# Altium schematic sheet B216.SchDoc(B216)
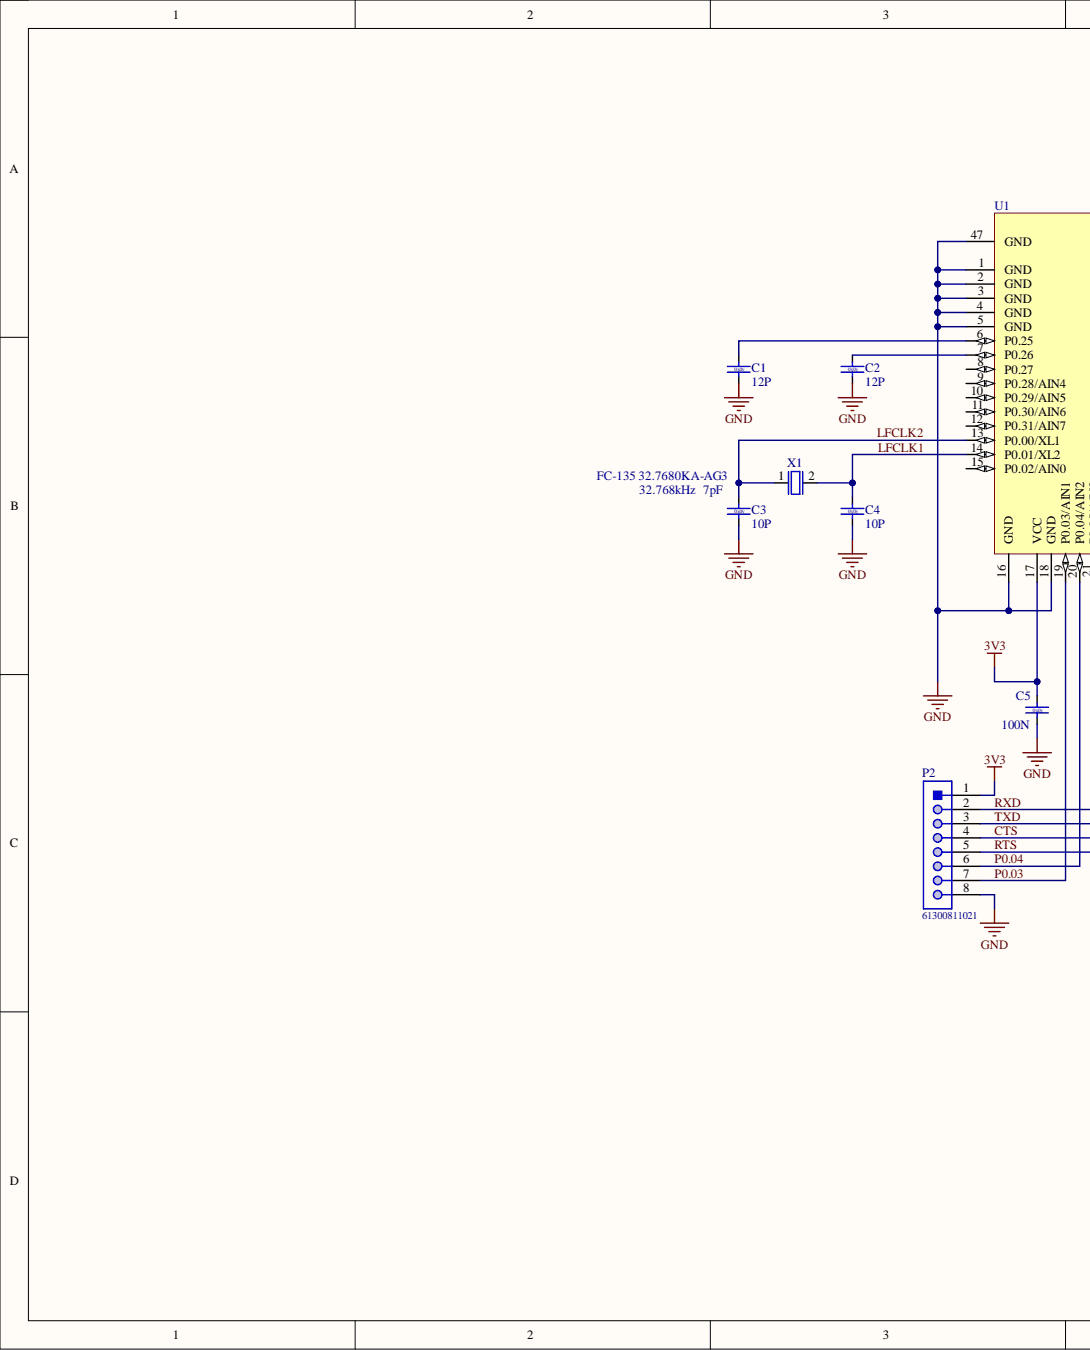
[diagram p0: B216.SchDoc, left]
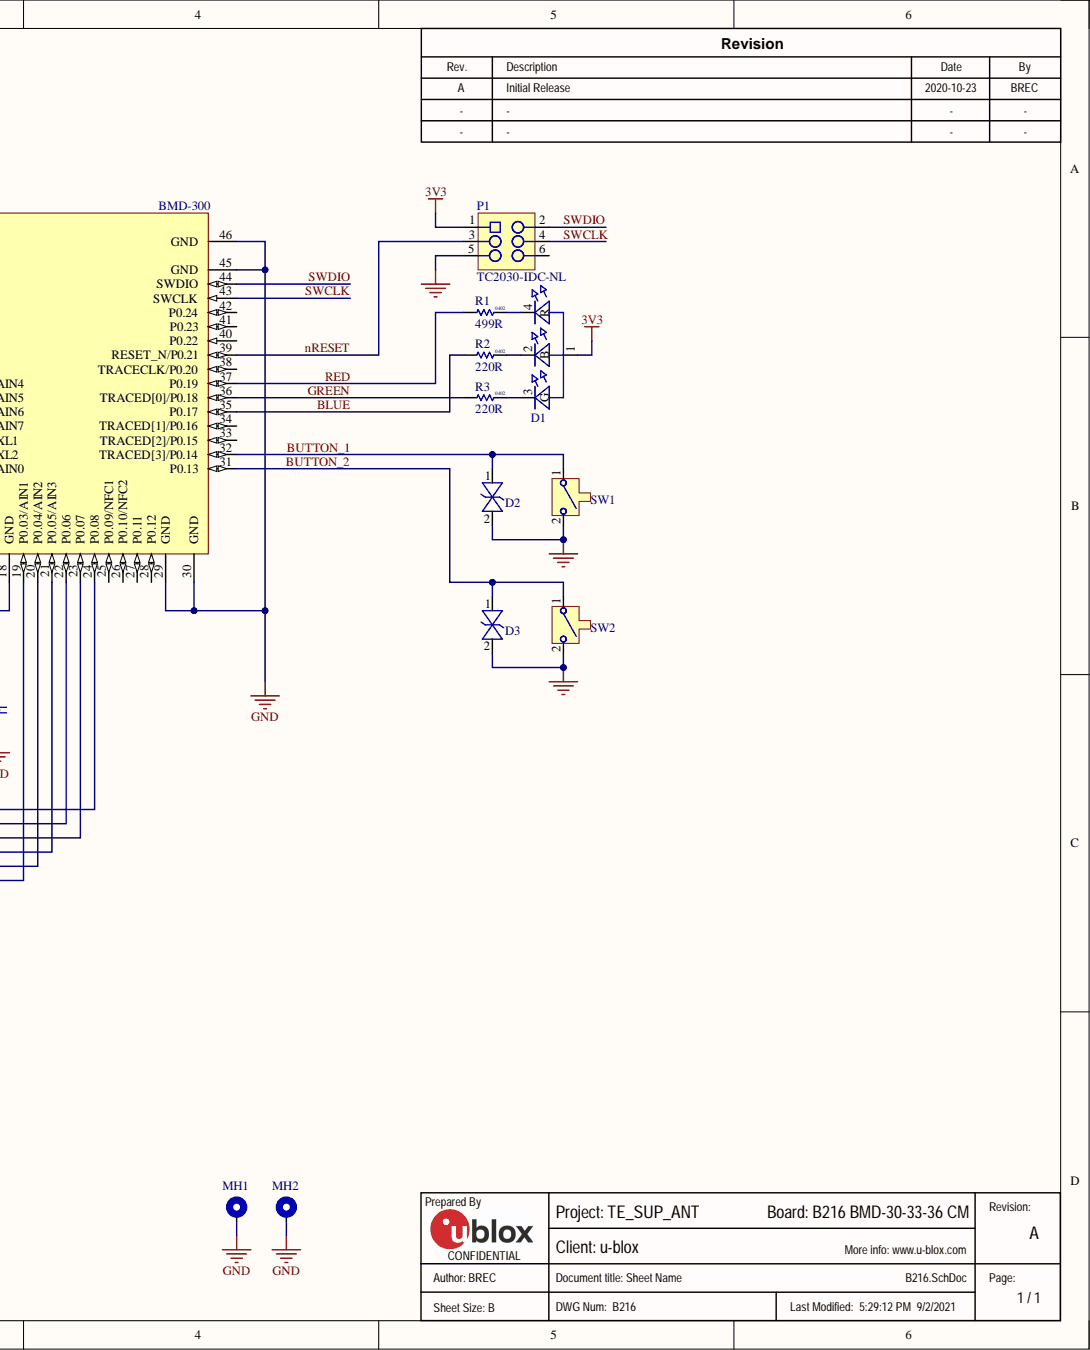
[diagram p1: B216.SchDoc, right]

## Parts
C1  value 12P  Size: 0x0y  [Cap 0402]  (hidden comment: UBXH01-000801512P)
C2  value 12P  Size: 0x0y  [Cap 0402]  (hidden comment: UBXH01-000801512P)
C3  value 10P  Size: 0x0y  [Cap 0402]  (hidden comment: UBXH01-000411410P)
C4  value 10P  Size: 0x0y  [Cap 0402]  (hidden comment: UBXH01-000411410P)
C5  value 100N  Size: 0x0y  [Cap 0402]  (hidden comment: UBXH01-2021002100N)
D1  115-00011  [4-PLCC]  (value not drawn)
D2  110-00019  [SOD-923]  (value not drawn)
D3  110-00019  [SOD-923]  (value not drawn)
MH1  MH_M1.5DNP  [MH_M1.5]  (value not drawn)
MH2  MH_M1.5DNP  [MH_M1.5]  (value not drawn)
P1  TC2030-IDC-NLDNP  [TC2030-IDC-NL]  (value not drawn)
P2  61300811021  [61300811021]
R1  value 499R  Size: 0402  [RES 0402 HD]  (hidden comment: 180-00031499R)
R2  value 220R  Size: 0402  [RES 0402 HD]  (hidden comment: 180-00098220R)
R3  value 220R  Size: 0402  [RES 0402 HD]  (hidden comment: 180-00098220R)
SW1  135-00007  [B3U-1000P]  (value not drawn)
SW2  135-00007  [B3U-1000P]  (value not drawn)
U1  =Value  [BMD_LGA47S_1400X980X190_PCB]
U1
U1
X1  100-00005  [XTAL_3215]  (value not drawn)

## Nets
3V3: C5.1 D1.1 P1.1 P2.1 U1.17
GND: C1.2 C2.2 C3.2 C4.2 C5.2 D2.2 D3.2 MH1.1 MH2.1 P1.5 P2.8 SW1.2 SW2.2 U1.1 U1.2 U1.3 U1.4 U1.5 U1.16 U1.18 U1.29 U1.30 U1.45 U1.46 U1.47
BLUE: R2.1 U1.35
BUTTON_1: D2.1 SW1.1 U1.32
BUTTON_2: D3.1 SW2.1 U1.31
CTS: P2.4 U1.23
GREEN: R3.1 U1.36
LFCLK1: C4.1 U1.14 X1.2
LFCLK2: C3.1 U1.13 X1.1
nRESET: P1.3 U1.39
P0.03: P2.7 U1.19
P0.04: P2.6 U1.20
RED: R1.1 U1.37
RTS: P2.5 U1.21
RXD: P2.2 U1.24
SWCLK: P1.4 U1.43
SWDIO: P1.2 U1.44
TXD: P2.3 U1.22
NetC1_1: C1.1 U1.6
NetC2_1: C2.1 U1.7
NetD1_2: D1.2(B) R2.2
NetD1_3: D1.3(G) R3.2
NetD1_4: D1.4(R) R1.2
NetU1_8: U1.8
NetU1_9: U1.9
NetU1_10: U1.10
NetU1_11: U1.11
NetU1_12: U1.12
NetU1_15: U1.15
NetU1_25: U1.25
NetU1_26: U1.26
NetU1_27: U1.27
NetU1_28: U1.28
NetU1_33: U1.33
NetU1_34: U1.34
NetU1_38: U1.38
NetU1_40: U1.40
NetU1_41: U1.41
NetU1_42: U1.42

Not connected: P1 6

## Library data of the parts
C1 C2 = UBXH01-0008015: CAP CER COG 0402; Manufacturer: Murata Manufacturing Company, Ltd.; Manufacturer P/N: GRM1555C1H120KZ01; Critical: None; Database Table Name: Passive - Capacitor; Editor: andreas.thiel; Env: ROHS; Max Voltage: 25.0V; Mfg P/N Released: No; Mfg P/N Split: 0; Mfg P/N Status: Obsolete; Perferred: NONPREF; Permitted Use: Volume Products; Tolerance: 10%; Automotive: None; Component Kind: Standard; Library Name: UBX_Parts_DB_Lib.DbLib; Manufacturer 2: None; Manufacturer 3: None; Manufacturer P/N 2: None; Manufacturer P/N 3: None; Max Current: None; Max Operating Temp: None; Max Power: None; Mfg P/N Datasheet: None; Mfg P/N Datasheet 2: None; Mfg P/N Datasheet 3: None; Mfg P/N Released 2: None; Mfg P/N Released 3: None; Mfg P/N Split 2: None; Mfg P/N Split 3: None; Mfg P/N Status 2: None; Mfg P/N Status 3: None; Min Operating Temp: None; Part Number: UBXH01-0008015; Part Type: Capacitor; RefDes: C; Release Data: None; Signal Integrity: Cap Semi; Simulation: CAP; Single Source: None; UBX Wiki: https://wiki.u-blox.com/bin/view/Operations/PartDetails?index=5383; Released by: None
C3 C4 = UBXH01-0004114: CAP CER COG 0402; Manufacturer: Murata Manufacturing Company, Ltd.; Manufacturer P/N: GRM1555C1H100CZ01; Critical: None; Database Table Name: Passive - Capacitor; Editor: martin.frauchiger; Env: ROHS; Max Voltage: 25.0V; Mfg P/N Released: Yes; Mfg P/N Split: 0; Mfg P/N Status: Obsolete; Perferred: PREF; Permitted Use: Volume Products; Tolerance: 5%; Automotive: None; Component Kind: Standard; Library Name: UBX_Parts_DB_Lib.DbLib; Manufacturer 2: None; Manufacturer 3: None; Manufacturer P/N 2: None; Manufacturer P/N 3: None; Max Current: None; Max Operating Temp: None; Max Power: None; Mfg P/N Datasheet: None; Mfg P/N Datasheet 2: None; Mfg P/N Datasheet 3: None; Mfg P/N Released 2: None; Mfg P/N Released 3: None; Mfg P/N Split 2: None; Mfg P/N Split 3: None; Mfg P/N Status 2: None; Mfg P/N Status 3: None; Min Operating Temp: None; Part Number: UBXH01-0004114; Part Type: Capacitor; RefDes: C; Release Data: 2005-09-01 15:36:53; Signal Integrity: Cap Semi; Simulation: CAP; Single Source: None; UBX Wiki: https://wiki.u-blox.com/bin/view/Operations/PartDetails?index=5225; Released by: martin.frauchiger
C5 = UBXH01-2021002: CAP CER X5R 0402; Manufacturer: Samsung Electro-Mechanics; Manufacturer P/N: CL05A104KP5NNN; Critical: None; Database Table Name: Passive - Capacitor; Editor: roland.rust; Env: GREEN; Max Voltage: 10.0V; Mfg P/N Released: Yes; Mfg P/N Split: 0; Mfg P/N Status: Active; Perferred: PREF; Permitted Use: Volume Products; Tolerance: 10%; Automotive: None; Component Kind: Standard; Library Name: UBX_Parts_DB_Lib.DbLib; Manufacturer 2: None; Manufacturer 3: None; Manufacturer P/N 2: None; Manufacturer P/N 3: None; Max Current: None; Max Operating Temp: None; Max Power: None; Mfg P/N Datasheet: https://wiki.u-blox.com/bin/view/Generic/Docs/DocumentSummary?index=28113; Mfg P/N Datasheet 2: None; Mfg P/N Datasheet 3: None; Mfg P/N Released 2: None; Mfg P/N Released 3: None; Mfg P/N Split 2: None; Mfg P/N Split 3: None; Mfg P/N Status 2: None; Mfg P/N Status 3: None; Min Operating Temp: None; Part Number: UBXH01-2021002; Part Type: Capacitor; RefDes: C; Release Data: 2005-07-22 13:59:44; Signal Integrity: Cap Semi; Simulation: CAP; Single Source: None; UBX Wiki: https://wiki.u-blox.com/bin/view/Operations/PartDetails?index=5759; Released by: roland.rust
D1 = 115-00011: LED, RGB Diffused SMT, PLCC4 4 Pin; Manufacturer: Cree, Inc.; Manufacturer P/N: CLMVB-FKA-CFHEHLCBB7a363; Part Name: LED RGB SMT 2mm x 2mm; Body Size: 2.0mm x 2.0mm; Current: 20mA Red, 10mA Green, 10mA, Blue; Item_Phase: Production; Number of Circuits: 3; Use in new designs: Yes; Vf: 2V Red, 3V Green, 3V Blue; Voltage: 5V; Arena Link: https://app.bom.com/a/UCV39GZGZIHY581D?v=spec.summary; Color: RGB; Component Kind: Standard; Component Mounting Type: SMT; Database Table Name: LED; Height: 1mm; MFG Package: PLCC4; Mounting Type: Vertical; Package Type: Custom; Part Number: 115-00011; Part_Type: LED; Pins: 4; Revision: 0A; Supplier 1: Mouser; Supplier Part Number 1: 941-CLMVBFKACFHEHLCB; Library Name: Arena_Lib.DbLib
D2 D3 = 110-00019: Diode, ESD9B, 3.3V, ST5G, SOD-923; Manufacturer: ON Semiconductor; Manufacturer P/N: ESD9B3.3ST5G; Part Name: Diode TVS 3.3V SOD-923; Body Size: 0.8mm x 0.6mm; Component Mounting Type: SMT; Diode Type: TVS; Item_Phase: Production; Use in new designs: Yes; Arena Link: https://app.bom.com/a/4M5DJQ9Q9SR7DV4H?v=spec.summary; Component Kind: Standard; Database Table Name: Diode; Height: 0.4mm; MFG Package: SOD-923; Package Type: SOD; Part Number: 110-00019; Part_Type: Diode; Pins: 2; Revision: 0A; Supplier 1: Arrow; Supplier Part Number 1: ESD9B3.3ST5G; Voltage: 3.3V; Library Name: Arena_Lib.DbLib
MH1 MH2 = MH_M1.5: 1.5M screw mounting hole, plated; Added By: Jeremy D; Database Table Name: Mechanical; Added Date: 7/9/2015; Component Kind: Standard; DNP: DNP; Height: 0mm; Part Number: MH_M1.5; Size: 3.4mm x 3.4mm; Type: Mounting Hole; Library Name: LEGACY_Rigado_AltiumLib.DbLib
P1 = TC2030-IDC-NL: CON PADS FOR CBL PLUG-OF-NAILS 6-PIN NO LEGS; Manufacturer: TAG Connect; Added By: Paul B; Database Table Name: Testpoints & Pads; Added Date: 4/22/2015; Component Kind: Standard; DNP: DNP; Height: 0; Manufacturer P/N: TC2030-IDC-NL; Part Number: TC2030-IDC-NL; Size: 6.731mm x 12.446mm; Library Name: LEGACY_Rigado_AltiumLib.DbLib
P2 = CMP-1502-01075-1: THT Angled Pin Header WR-PHD, Pitch 2.54 mm, Single Row, 8 pins; Manufacturer: Wurth Electronics; Contact Resistance: 20mOhm; Gender: Male; Pin Number: 8; Pitch: 2.54mm; Rated Current: 3A; Withstanding Voltage: 500VAC; Working Voltage: 250VAC; Component Kind: Standard; Datasheet URL: https://katalog.we-online.de/em/datasheet/6130xx11021.pdf; Library Name: 8-pin-ra-100mil.IntLib; Manufacturer Part Number: 61300811021; Manufacturer URL: http://www.we-online.de; Mounting Technology: THT; Operating Temperature: -40to105degC; Orientation: Angled; Package Reference: 61300811021; Packaging: Bag; Part Number: 61300811021; Row Number: Single; Publisher: WurthElektronik
R1 = 180-00031: Resistor, 499ohm, 1%, 1/16W, 0402; Manufacturer: Rohm Semiconductor; Manufacturer P/N: MCR01MRTF4990; Part Name: RES SMD 499 OHM 1% 1/16W 0402; Component Mounting Type: SMT; Item_Phase: Production; Tolerance: +/- 1%; Use in new designs: Yes; Alternate Footprint Ref: RES 0402; Arena Link: https://app.bom.com/a/Q8RZ5CVCVEDU1SS5?v=spec.summary; Component Kind: Standard; Database Table Name: Resistor; Height: 0.4mm; Manufacturer 2: Samsung Electro-Mechanics America, Inc; Manufacturer P/N 2: RC1005F4990CS; Part Number: 180-00031; Part_Type: Resistor; Pins: 2; Power: 1/16W; Revision: 0D; Signal Integrity: Res; Simulation: RESISTOR; Supplier 1: Digi-Key; Supplier 2: Digi-Key; Supplier Part Number 1: RHM499CDCT-ND; Supplier Part Number 2: 1276-3992-1-ND; Library Name: Arena_Lib.DbLib
R2 R3 = 180-00098: Resistor, 220ohm, 5%, 1/16W, 0402.; Manufacturer: Panasonic Electronic Components; Manufacturer P/N: ERJ-2GEJ221X; Part Name: RES SMD 220 OHM 5% 1/16W 0402; Component Mounting Type: SMT; Item_Phase: Production; Tolerance: +/- 5%; Use in new designs: Yes; Alternate Footprint Ref: RES 0402; Arena Link: https://app.bom.com/a/8Q9HNUDUDWVBJC30?v=spec.summary; Component Kind: Standard; Database Table Name: Resistor; Height: 0.4mm; Manufacturer 2: Samsung Electro-Mechanics America, Inc; Manufacturer P/N 2: RC1005J221CS; Part Number: 180-00098; Part_Type: Resistor; Pins: 2; Power: 1/16W; Revision: 0C; Signal Integrity: Res; Simulation: RESISTOR; Supplier 1: Digi-Key; Supplier 2: Digi-Key; Supplier Part Number 1: P220JCT-ND; Supplier Part Number 2: 1276-4360-1-ND; Library Name: Arena_Lib.DbLib
SW1 SW2 = 135-00007: Button, Momentary SPST-NO, 0.05A, 12V, 3mm x 2.5mm; Manufacturer: Omron Electronics Inc-EMC Div; Part Name: SWITCH TACTILE SPST-NO 0.05A 12V; Component Mounting Type: SMT; Current: 50mA; Item_Phase: Production; Switch/Button Circuit: SPST; Switch/Button Function: Off-Mom; Use in new designs: Yes; Voltage: 12VDC; Arena Link: https://app.bom.com/a/TBU28FYFYHGV5FQ0?v=spec.summary; Body Size: 3mm x 2.5mm; Component Kind: Standard; Database Table Name: Button; Height: 1.2mm; Manufacturer P/N: B3U-1000P; Part Number: 135-00007; Part_Type: Button; Pins: 2; Revision: 0A; Supplier 1: Digi-Key; Supplier Part Number 1: SW1020CT-ND; Library Name: Arena_Lib.DbLib
U1 = BMD-300: u-blox BMD-300 Bluetooth 5 LE module; Manufacturer P/N: BMD-300-A-R; Body Size: 14mm x 9.8mm; Internal Antenna: PCB; Protocol: BT 5 LE, 2.4GHz Proprietary; Component Kind: Standard; Height: 1.9mm; Library Name: ubloxLib.IntLib; Manufacturer: u-blox; Part Number: BMD-300
X1 = 100-00005: XTAL, 2Pin, 32.768kHz, 7pF 20ppm; Manufacturer: Epson; Manufacturer P/N: FC-135 32.7680KA-AG3; Part Name: XTAL 32.768kHz 7pF 20ppm 3215; Body Size: 3.2mm x 1.5mm; Component Mounting Type: SMT; Database Table Name: Crystal/Oscillator; Item_Phase: Production; MFG Package: 2-SMD; Use in new designs: Yes; Arena Link: https://app.bom.com/a/L3MU07Q7Q98O5PC8?v=spec.summary; CL: 7pF; Component Kind: Standard; Frequency: 32.768kHz; Frequency Tolerance: 20ppm; Height: 0.9mm; Manufacturer 2: AVX Corporation; Manufacturer P/N 2: ST3215SB32768C0HPWBB; Part Number: 100-00005; Part_Type: Crystal/Oscillator; Pins: 2; Revision: 0C; Supplier 1: Digi-Key; Supplier 2: Digi-Key; Supplier Part Number 1: SER4086CT-ND; Supplier Part Number 2: 1253-1113-1-ND; Library Name: Arena_Lib.DbLib
